# Altium schematic sheet TI_92_main.SchDoc
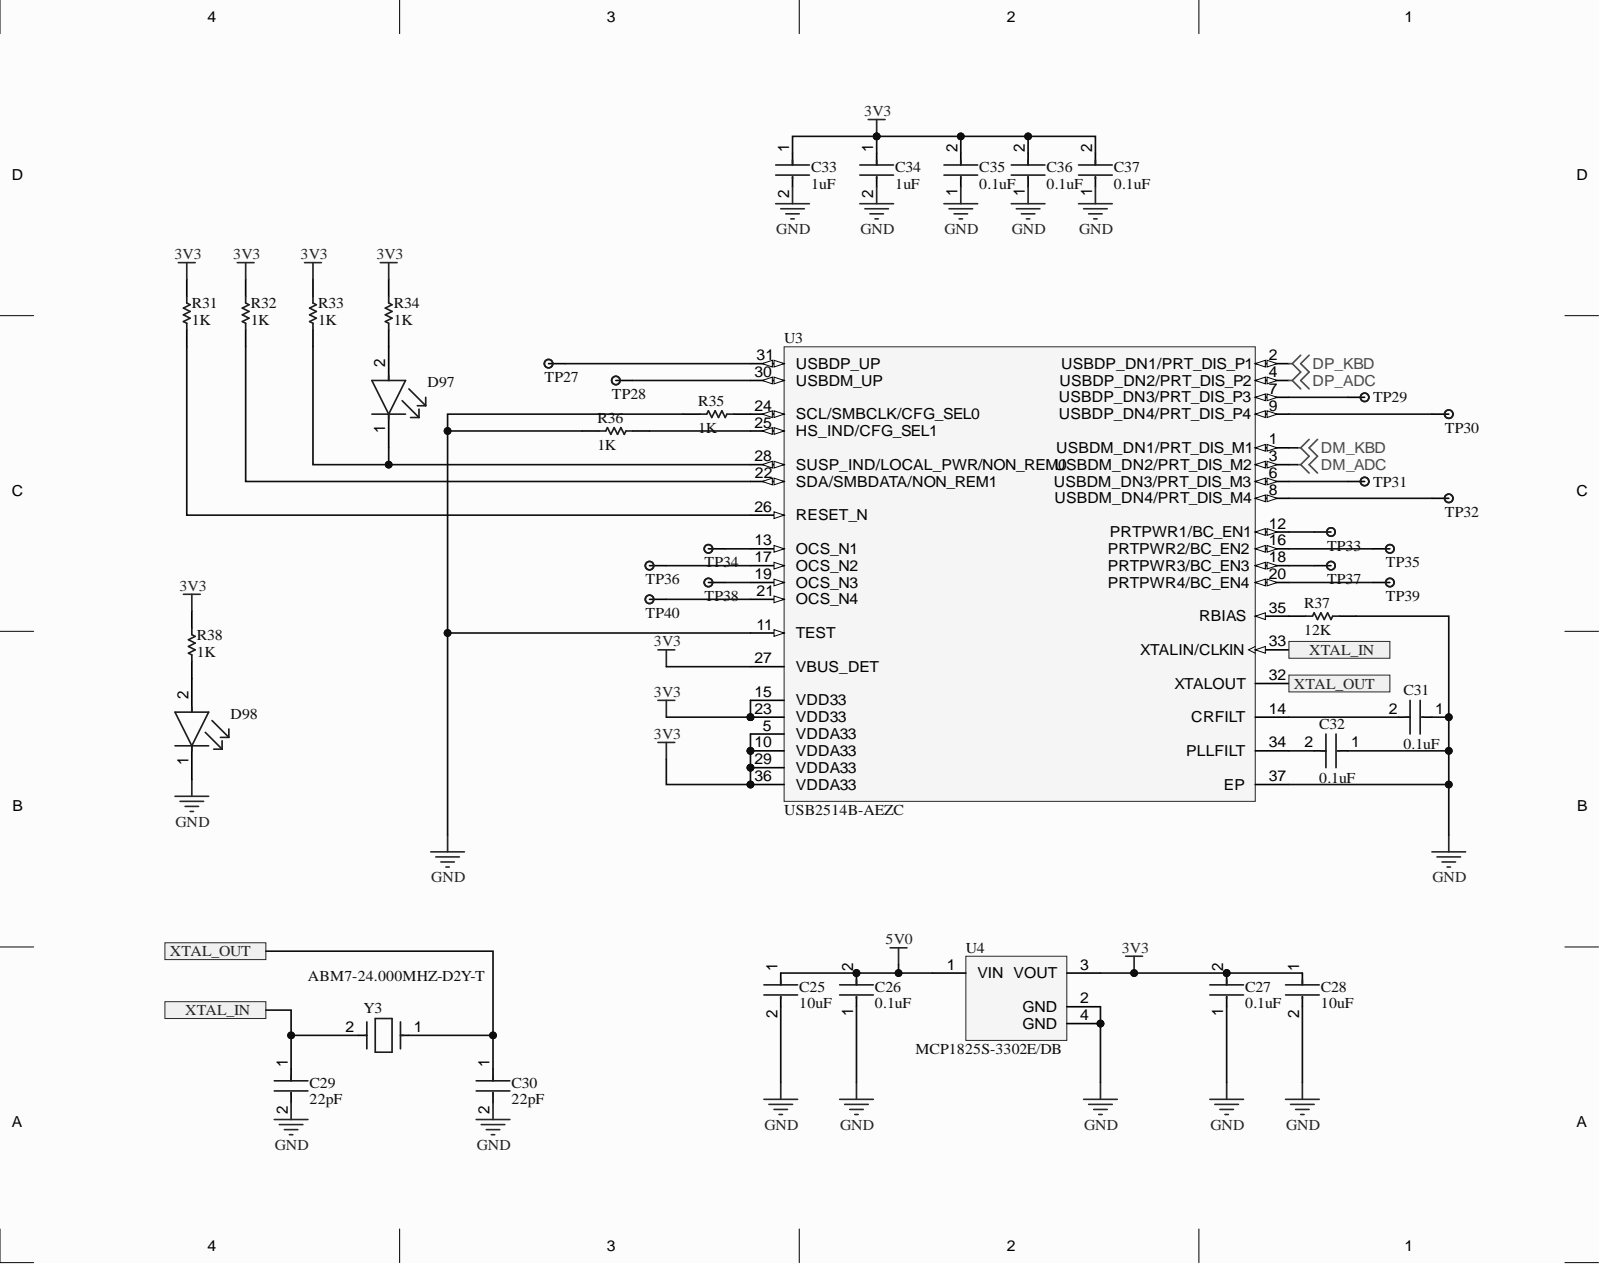
[diagram p0: TI_92_main.SchDoc]

## Parts
C25  10uF  [FP-0603-L_1_6_0_2-W_0_8_0-MFG]
C26  0.1uF  [FP-GRM15-MFG]
C27  0.1uF  [FP-GRM15-MFG]
C28  10uF  [FP-0603-L_1_6_0_2-W_0_8_0-MFG]
C29  22pF  [FP-0402-L_1_0_1-W_0_5_0_1-MFG]
C30  22pF  [FP-C0402C105K8PACTU-MFG]
C31  0.1uF  [FP-GRM15-MFG]
C32  0.1uF  [FP-GRM15-MFG]
C33  1uF  [FP-C0402C105K8PACTU-MFG]
C34  1uF  [FP-C0402C105K8PACTU-MFG]
C35  0.1uF  [FP-GRM15-MFG]
C36  0.1uF  [FP-GRM15-MFG]
C37  0.1uF  [FP-GRM15-MFG]
D97  APHHS1005CGCK  [FP-APHHS1005CGCK-MFG]  (value not drawn)
D98  APHHS1005CGCK  [FP-APHHS1005CGCK-MFG]  (value not drawn)
R31  1K  [RESC1005X40X25NL05T10]
R32  1K  [RESC1005X40X25NL05T10]
R33  1K  [RESC1005X40X25NL05T10]
R34  1K  [RESC1005X40X25NL05T10]
R35  1K  [RESC1005X40X25NL05T10]
R36  1K  [RESC1005X40X25NL05T10]
R37  12K  [RESC1005X40X25NL05T10]
R38  1K  [RESC1005X40X25NL05T10]
TP27  Test Pad  [2.5MM_PAD]  (value not drawn)
TP28  Test Pad  [2.5MM_PAD]  (value not drawn)
TP29  Test Pad  [2.5MM_PAD]  (value not drawn)
TP30  Test Pad  [2.5MM_PAD]  (value not drawn)
TP31  Test Pad  [2.5MM_PAD]  (value not drawn)
TP32  Test Pad  [2.5MM_PAD]  (value not drawn)
TP33  Test Pad  [1.0mm_Pad]  (value not drawn)
TP34  Test Pad  [1.0mm_Pad]  (value not drawn)
TP35  Test Pad  [1.0mm_Pad]  (value not drawn)
TP36  Test Pad  [1.0mm_Pad]  (value not drawn)
TP37  Test Pad  [1.0mm_Pad]  (value not drawn)
TP38  Test Pad  [1.0mm_Pad]  (value not drawn)
TP39  Test Pad  [1.0mm_Pad]  (value not drawn)
TP40  Test Pad  [1.0mm_Pad]  (value not drawn)
U3  USB2514B-AEZC  [MCHP-QFN-36_V]
U4  MCP1825S-3302E/DB  [SOT-223-DB3_N]
Y3  ABM7-24.000MHZ-D2Y-T  [FP-ABM7-MFG]

## Ports
XTAL_IN, XTAL_OUT

## Nets
3V3: C27.2 C28.1 C33.1 C34.1 C35.2 C36.2 C37.2 R31.2 R32.2 R33.2 R34.2 R38.2 U3.5(VDDA33) U3.10(VDDA33) U3.15(VDD33) U3.23(VDD33) U3.27(VBUS_DET) U3.29(VDDA33) U3.36(VDDA33) U4.3(VOUT)
5V0: C25.1 C26.2 U4.1(VIN)
DM_ADC: U3.3(USBDM_DN2/PRT_DIS_M2)
DM_KBD: U3.1(USBDM_DN1/PRT_DIS_M1)
DP_ADC: U3.4(USBDP_DN2/PRT_DIS_P2)
DP_KBD: U3.2(USBDP_DN1/PRT_DIS_P1)
GND: C25.2 C26.1 C27.1 C28.2 C29.2 C30.2 C31.1 C32.1 C33.2 C34.2 C35.1 C36.1 C37.1 D98.1 R35.2 R36.2 R37.2 U3.11(TEST) U3.37(EP) U4.2(GND) U4.4(GND)
XTAL_IN: C29.1 U3.33(XTALIN/CLKIN) Y3.2 ; port XTAL_IN
XTAL_OUT: C30.1 U3.32(XTALOUT) Y3.1 ; port XTAL_OUT
NetC31_2: C31.2 U3.14(CRFILT)
NetC32_2: C32.2 U3.34(PLLFILT)
NetD97_1: D97.1 R33.1 U3.28(SUSP_IND/LOCAL_PWR/NON_REM0)
NetD97_2: D97.2 R34.1
NetD98_2: D98.2 R38.1
NetR31_1: R31.1 U3.26(RESET_N)
NetR32_1: R32.1 U3.22(SDA/SMBDATA/NON_REM1)
NetR35_1: R35.1 U3.24(SCL/SMBCLK/CFG_SEL0)
NetR36_1: R36.1 U3.25(HS_IND/CFG_SEL1)
NetR37_1: R37.1 U3.35(RBIAS)
NetTP27_1: TP27.1 U3.31(USBDP_UP)
NetTP28_1: TP28.1 U3.30(USBDM_UP)
NetTP29_1: TP29.1 U3.7(USBDP_DN3/PRT_DIS_P3)
NetTP30_1: TP30.1 U3.9(USBDP_DN4/PRT_DIS_P4)
NetTP31_1: TP31.1 U3.6(USBDM_DN3/PRT_DIS_M3)
NetTP32_1: TP32.1 U3.8(USBDM_DN4/PRT_DIS_M4)
NetTP33_1: TP33.1 U3.12(PRTPWR1/BC_EN1)
NetTP34_1: TP34.1 U3.13(OCS_N1)
NetTP35_1: TP35.1 U3.16(PRTPWR2/BC_EN2)
NetTP36_1: TP36.1 U3.17(OCS_N2)
NetTP37_1: TP37.1 U3.18(PRTPWR3/BC_EN3)
NetTP38_1: TP38.1 U3.19(OCS_N3)
NetTP39_1: TP39.1 U3.20(PRTPWR4/BC_EN4)
NetTP40_1: TP40.1 U3.21(OCS_N4)

## Library data of the parts
C25 C28 = CMP-14477-005164-2: Multilayer Ceramic Capacitor 10uF 25V X5R 20% SMD 0603 T/R; Capacitance: 10uF; Case Code (Imperial): 0603; Case Code (Metric): 1608; Case/Package: 0603; Component Kind: Standard; Lead Free: Lead Free; Library Name: Altium Content Vault; Max Operating Temperature: 85°C; Min Operating Temperature: -55°C; Mount: Surface Mount; Packaging: Tape and Reel; RoHS Compliant: Yes; Tolerance: 20%; Voltage Rating: 25V
C26 C27 C31 C32 C35-C37 = CMP-2100-03641-2: CAP CER 0.1UF 16V X7R 0402; Manufacturer: Murata; Manufacturer Part Number: GRM155R71C104KA88D; Capacitance: 0.10μF; Material: Ceramic; Standoff Height: 0mm; Tolerance: 10%; Value: 0.10μF; Voltage Rating: 16Vdc; Category: Cap; Component Kind: Standard; ComponentLink2Description: Datasheet; ComponentLink2URL: https://search.murata.co.jp/Ceramy/image/img/A01X/G101/ENG/GRM155R71H471KA01-01A.pdf; Device Class L1: Passive Components; Device Class L2: Capacitors; Device Class L3: Ceramic Capacitors; Digikey Description: CAP CER 0.1UF 16V X7R 0402; Height: 0.55mm; Ipc Land Pattern Name: CAPC10050X219; Lead Free: yes; Library Name: Altium Content Vault; Mouser Description: 0402 0.1 uF 16V ±10 % Tolerance X7R SMT Multilayer Ceramic Capacitor; Octopart: https://octopart.com/grm155r71c104ka88d-murata-196880; Package: GRM15; RoHS: TRUE; Temperature: -55°C to +125°C; Temperature Characteristic: X7R; Temperature Range High: +125°C; Temperature Range Low: -55°C
C29 = CMP-2008-02613-2: General Purpose Ceramic Capacitor, 0402, 22pF, 5%, C0G, 30ppm/°C, 50V; Capacitance: 22pF; Case Code (Imperial): 0402; Case Code (Metric): 1005; Case/Package: 0402; Component Kind: Standard; Construction: Flat; Contact Plating: Tin; Depth: 0.5mm; Dielectric: C0G; Height: 0.5mm; Lead Free: Lead Free; Length: 1mm; Library Name: Altium Content Vault; Max Operating Temperature: 125°C; Min Operating Temperature: -55°C; Mount: Surface Mount; Number of Pins: 2; Package Quantity: 1; Packaging: Tape and Reel; Radiation Hardening: No; REACH SVHC: No SVHC; RoHS Compliant: Yes; Series: -; Temperature Coefficient: 30ppm/°C; Termination: SMD/SMT; Thickness: 0.022inch; Tolerance: 5%; Voltage: 50V; Voltage Rating: 50V; Voltage Rating (DC): 50V; Width: 0.02inch
C30 C33 C34 = CMP-2008-02081-2: CAP CER 1UF 10V X5R 0402; Dielectric Material: Ceramic; Dissipation Factor: 5%; Tolerance: 10%; Capacitance: 1uF; Case Code (Imperial): 0402; Case Code (Metric): 1005; Case/Package: 0402; Component Kind: Standard; Construction: Flat; Contact Plating: Tin; Depth: 0.5mm; Dielectric: X5R; Height: 0.5mm; Lead Free: Lead Free; Length: 1mm; Library Name: Altium Content Vault; Max Operating Temperature: 85°C; Min Operating Temperature: -55°C; Mount: Surface Mount; Number of Pins: 2; Package Quantity: 1; Packaging: Tape and Reel; Radiation Hardening: No; REACH SVHC: No SVHC; RoHS Compliant: Yes; Series: C; Termination: SMD/SMT; Thickness: 0.022inch; Voltage: 10V; Voltage Rating: 10V; Voltage Rating (DC): 10V; Width: 0.5mm
D97 D98 = CMP-44529-000044-1: LED GREEN CLEAR CHIP SMD; Dominant Wavelength: 570nm; Forward Current: 20mA; Forward Voltage: 2.1V; Luminous Intensity: 50mcd; Number of LEDs: 1; Viewing Angle: 120°; Case Code (Imperial): 0402; Case Code (Metric): 1005; Case/Package: 0402; Color: Green; Component Kind: Standard; Height: 0.6mm; Illumination Color: Green; Lead Free: Lead Free; Length: 1mm; Lens Color: Clear; Lens Style: Clear; Library Name: Altium Content Vault; Max Operating Temperature: 85°C; Min Operating Temperature: -40°C; Mount: Surface Mount; Number of Pins: 2; Package Quantity: 2000; Packaging: Tape and Reel; Test Current: 20mA; Wavelength: 570nm; Width: 0.5mm
R31-R38 = CMP-2002-07472-1: Manufacturer: Yageo / Phycomp; Part Number: RC0402FR-071KL; Component Kind: Standard; Library Name: Altium Content Vault
TP27-TP40 = Test_Pad: Various Test Pads; Component Kind: Standard; Library Name: TI_kbd.SchLib
U3 = CMP-2000-05217-1: USB 2.0 High-Speed Hub Controller, 4 Ports, 3 to 3.6 V, 0 to 85 degC, 36-Pin QFN, RoHS, Tray; Manufacturer: Microchip; Current - Supply: 70mA; Interface: I²CEEPROM,SMBus(2-Wire,I²C); Other Names: 638-1122; Voltage - Supply: 3V~3.6V; Category: IntegratedCircuits(ICs); Component Kind: Standard; Datasheet URL: http://ww1.microchip.com/downloads/en/DeviceDoc/00001692C.pdf; Datasheet Version: DS00001692C, 10/2015; Family: Interface-Controllers; Function: -; Library Name: Altium Content Vault; Manufacturer URL: http://www.microchip.com/; Operating Temperature: -; Package / Case: 36-VFQFNExposedPad; Packaging: Tray; Protocol: -; Series: -; Standard Package: 490; Standards: -; Supplier Device Package: 36-QFN(6x6)
U4 = CMP-0136-00458-1: 500 mA, Low Voltage, Low Quiescent Current LDO Regulator, 3-Pin SOT-223, Extended Temperature; Component Kind: Standard; DatasheetVersion: revB, Feb-2008; Library Name: Altium Content Vault; PackageDescription: 3-Lead Plastic Small Outline Transistor (DB) [SOT-223]; PackageReference: SOT-223-DB3; PackageVersion: revBB, Aug-2009
Y3 = CMP-27762-000095-1: Crystal 16MHz ±20ppm 18pF SMD-2 6mm x 3.5mm; ESR (Equivalent Series Resistance): 50R; Frequency Stability: 30ppm; Case/Package: CSMD; Component Kind: Standard; Depth: 3.5mm; Frequency: 16MHz; Frequency Tolerance: 20ppm; Height: 0.055inch; Lead Free: Lead Free; Length: 6mm; Library Name: Altium Content Vault; Load Capacitance: 18pF; Max Operating Temperature: 85°C; Min Operating Temperature: -40°C; Mount: Surface Mount; Number of Pins: 2; Operating Frequency: 16 MHz; Package Quantity: 1000; Packaging: Tape and Reel; Radiation Hardening: No; Resistor Type: Ceramic; Series: ABM7; Termination: SMD/SMT; Tolerance: 20ppm; Width: 3.3mm
